# Altium schematic sheet AUDIO.SchDoc(AUDIO)
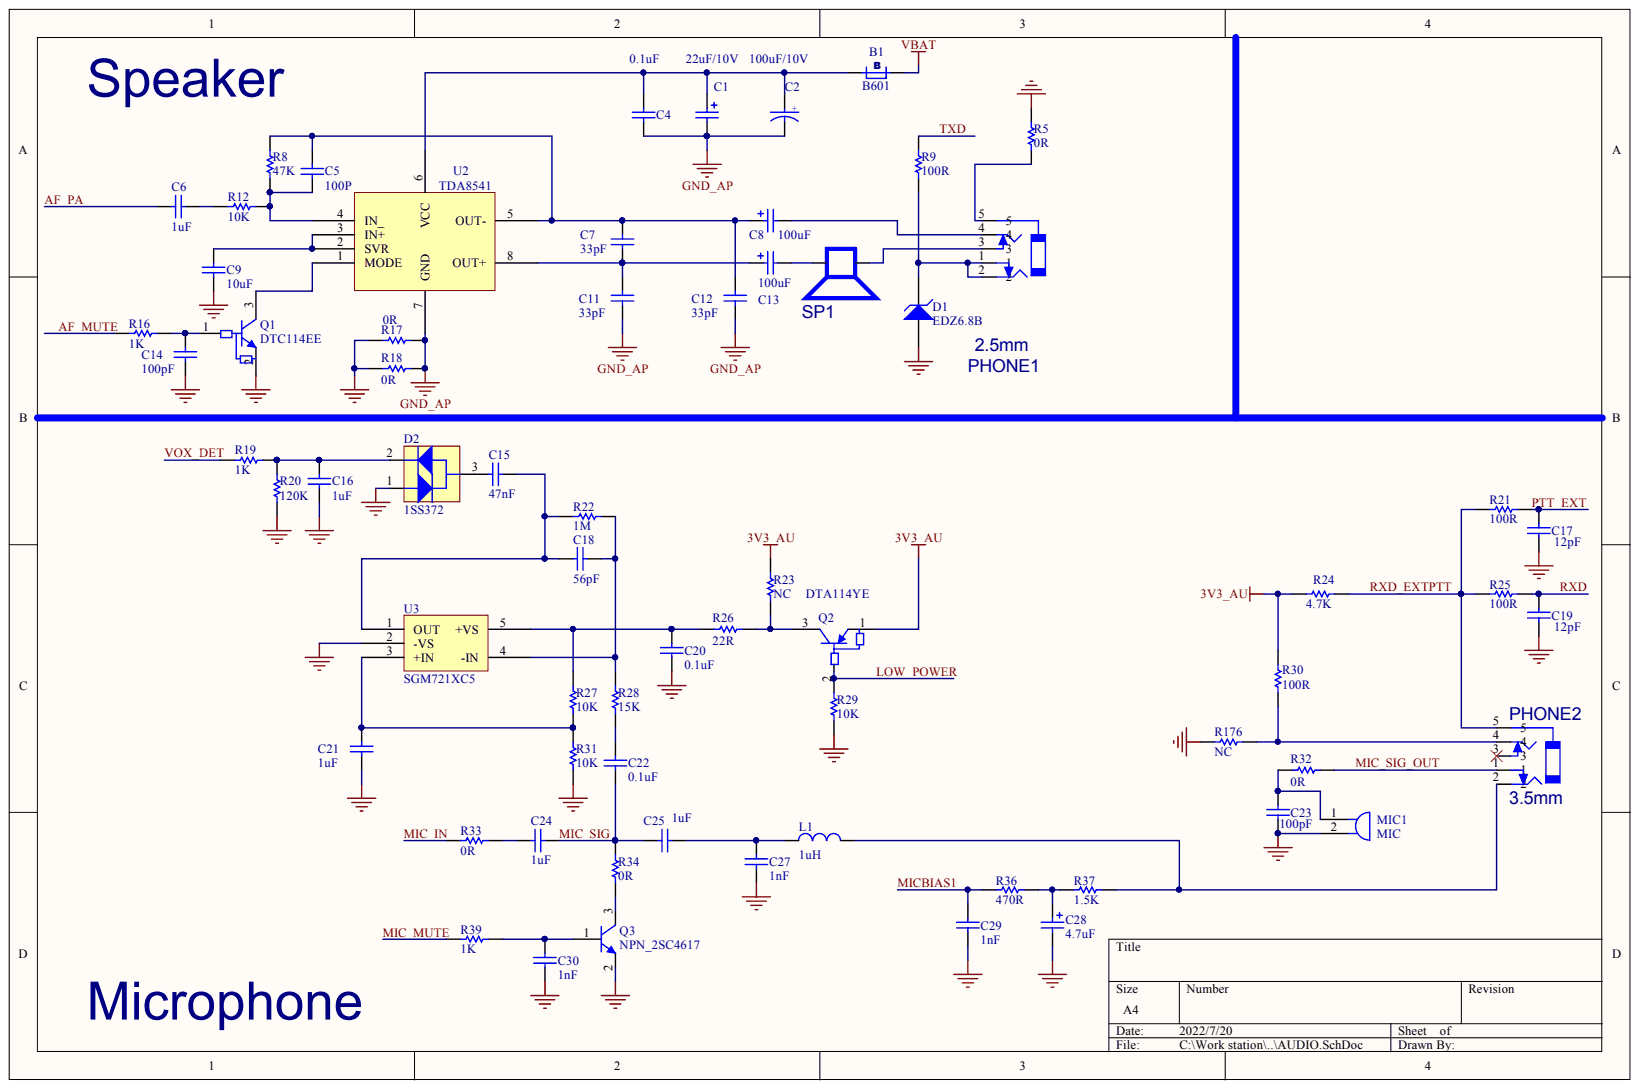
[diagram p0: AUDIO.SchDoc]

## Parts
B1  B601  [0603_MJ]
C1  22uF/10V  [0805]
C2  100uF/10V  [1210_Jun]
C4  0.1uF  [0402]
C5  100P  [0402]
C6  1uF  [0402]
C7  33pF  [0402]
C8  100uF  [C3216]
C9  10uF  [0603_MJ]
C11  33pF  [0402]
C12  33pF  [0402]
C13  100uF  [C3216]
C14  100pF  [0402]
C15  47nF  [0402]
C16  1uF  [0402]
C17  12pF  [0402]
C18  56pF  [0402]
C19  12pF  [0402]
C20  0.1uF  [0402]
C21  1uF  [0402]
C22  0.1uF  [0402]
C23  100pF  [0402]
C24  1uF  [0402]
C25  1uF  [0402]
C27  1nF  [0402]
C28  4.7uF  [0603]
C29  1nF  [0402]
C30  1nF  [0402]
D1  EDZ6.8B  [HVC131_SMALL]
D2  1SS372  [1ss372]
L1  1uH  [0603]
MIC1  MIC  [MIC-1]
PHONE1  2.5mm  [PHONE-2.5]
PHONE2  3.5mm  [PHONE-3.5]
Q1  DTC114EE  [SC-75]
Q2  DTA114YE  [DTA114YE_Jun]
Q3  NPN_2SC4617  [SC70-3_C]
R5  0R  [0402]
R8  47K  [0402]
R9  100R  [0402]
R12  10K  [0402]
R16  1K  [0402]
R17  0R  [0402]
R18  0R  [0402]
R19  1K  [0402]
R20  120K  [0402]
R21  100R  [0402]
R22  1M  [0402]
R23  NC  [0402]
R24  4.7K  [0402]
R25  100R  [0402]
R26  22R  [0402]
R27  10K  [0402]
R28  15K  [0402]
R29  10K  [0402]
R30  100R  [0402]
R31  10K  [0402]
R32  0R  [0402]
R33  0R  [0402]
R34  0R  [0402]
R36  470R  [0402]
R37  1.5K  [0402]
R39  1K  [0402]
R176  NC  [0402]
SP1  (no value)  [SP]
U2  TDA8541  [SOP-8-1.27]
U3  SGM721XC5  [SC-88A]

## Nets
3V3_AU: Q2.1 R23.2 R24.2 R30.2
GND: C9.2 C14.2 C16.2 C17.1 C19.1 C20.2 C21.2 C23.1 C27.2 C28.2 C29.1 C30.2 D1.1 D2.1 MIC1.2 Q1.2 Q3.2 R5.2 R17.2 R18.2 R20.2 R29.1 R31.1 R176.1 U3.2(-VS)
GND_AP: C1.2 C2.2 C4.1 C11.2 C12.2 R17.1 R18.1 U2.7(GND)
VBAT: B1.2
AF_MUTE: R16.1
AF_PA: C6.1
LOW_POWER: Q2.2 R29.2
MIC_IN: R33.2
MIC_MUTE: R39.2
MIC_SIG: C22.2 C24.2 C25.2 R34.2
MIC_SIG_OUT: PHONE2.1 R32.2
MICBIAS1: C29.2 R36.2
PTT_EXT: C17.2 R21.2
RXD: C19.2 R25.2
RXD_EXTPTT: PHONE2.5 R21.1 R24.1 R25.1
TXD: R9.2
VOX_DET: R19.1
NetB1_1: B1.1 C1.1 C2.1 C4.2 U2.6(VCC)
NetC5_1: C5.1 R8.1 R12.2 U2.4(IN_)
NetC5_2: C5.2 C7.1 C8.1 C12.1 R8.2 U2.5(OUT-)
NetC6_2: C6.2 R12.1
NetC7_2: C7.2 C11.1 C13.1 U2.8(OUT+)
NetC8_2: C8.2 PHONE1.4
NetC9_1: C9.1 U2.2(SVR) U2.3(IN+)
NetC13_2: C13.2 SP1.2
NetC14_1: C14.1 Q1.1 R16.2
NetC15_1: C15.1 D2.3
NetC15_2: C15.2 C18.1 R22.2 U3.1(OUT)
NetC16_1: C16.1 D2.2 R19.2 R20.1
NetC18_2: C18.2 R22.1 R28.2 U3.4(-IN)
NetC20_1: C20.1 R26.2 R27.2 U3.5(+VS)
NetC21_1: C21.1 R27.1 R31.2 U3.3(+IN)
NetC22_1: C22.1 R28.1
NetC23_2: C23.2 MIC1.1 R32.1
NetC24_1: C24.1 R33.1
NetC25_1: C25.1 C27.1 L1.2
NetC28_1: C28.1 R36.1 R37.2
NetC30_1: C30.1 Q3.1 R39.1
NetD1_2: D1.2 PHONE1.1 PHONE1.2 R9.1
NetL1_1: L1.1 PHONE2.2 R37.1
NetPHONE1_3: PHONE1.3 SP1.1
NetPHONE1_5: PHONE1.5 R5.1
NetPHONE2_4: PHONE2.4 R30.1 R176.2
NetQ1_3: Q1.3 U2.1(MODE)
NetQ2_3: Q2.3 R23.1 R26.1
NetQ3_3: Q3.3 R34.1

Not connected: PHONE2 3

## Library data of the parts
B1 = B: Component Kind: Standard; Library Name: SCH Lib.SCHLIB; Revision: July-2002: Re-released for DXP Platform.
C1 C8 C13 C28 = Cap Pol3: Polarized Capacitor (Surface Mount); Value: 4.7uF/10V; Component Kind: Standard; Library Name: SCH Lib.SCHLIB; Published: 8-Jun-2000; Revision: July-2002: Re-released for DXP Platform.; Signal Integrity: Cap Pol; Simulation: CAP; Publisher: Altium Limited
C2 = CAP_1210: Component Kind: Standard; Library Name: zySCHLIB_Z.SCHLIB
C4 C5 C7 C9 C11 C12 C14 C15 C17-C20 C22 C27 C29 C30 = Cap Semi_1: Capacitor (Semiconductor SIM Model); Value: 1uF; Component Kind: Standard; Library Name: SCH Lib.SCHLIB; Published: 8-Jun-2000; Revision: July-2002: Re-released for DXP Platform.; Signal Integrity: Cap Semi; Simulation: CAP; Publisher: Altium Limited
C6 C16 C21 C24 C25 = Cap Semi_1: Capacitor (Semiconductor SIM Model); Component Kind: Standard; Library Name: SCH Lib.SCHLIB; Published: 8-Jun-2000; Revision: July-2002: Re-released for DXP Platform.; Signal Integrity: Cap Semi; Simulation: CAP; Value: 1uF; Publisher: Altium Limited
C23 = Cap Semi_1: Capacitor (Semiconductor SIM Model); Value: 470pF; Component Kind: Standard; Library Name: SCH Lib.SCHLIB; Published: 8-Jun-2000; Revision: July-2002: Re-released for DXP Platform.; Signal Integrity: Cap Semi; Simulation: CAP; Publisher: Altium Limited
D1 = D Zener: Zener Diode; Manufacturer: Generic Components; Class: Discrete; SubClass: Diode - Zener; Component Kind: Standard; Library Name: Miscellaneous Devices.IntLib; Published: 08/06/2000; Signal Integrity: D Zener; Simulation: ZENER; Publisher: Altium Hobart Technology Centre
D2 = REC DIODE: Component Kind: Standard; Library Name: SCH Lib.SCHLIB
L1 = INDUCTOR: Component Kind: Standard; Library Name: SCH Lib.SCHLIB
MIC1 = Mic1: Microphone; Manufacturer: Generic Components; Component Kind: Standard; Library Name: Miscellaneous Devices.IntLib; Published: 1999
PHONE1 = JAKK_A: Component Kind: Standard; Library Name: zySCHLIB_Z.SCHLIB
PHONE2 = JAKK_A_1: Component Kind: Standard; Library Name: zySCHLIB_Z.SCHLIB
Q1 = DTC114EE_Jun: Component Kind: Standard; Library Name: zySCHLIB_Z.SCHLIB
Q2 = DTA114YE_Jun: Component Kind: Standard; Library Name: zySCHLIB_Z.SCHLIB
R5 R8 R9 R12 R17 R18 R20-R34 R36 R37 R176 = Res Semi: Semiconductor Resistor; Manufacturer: Generic Components; Class: Passive; Value: 1K; Component Kind: Standard; Library Name: Miscellaneous Devices.IntLib; Published: 08/06/2000; Signal Integrity: Res Semi; Simulation: RES; SubClass: Resistor; Publisher: Altium Hobart Technology Centre
R16 R19 R39 = Res Semi: Semiconductor Resistor; Manufacturer: Generic Components; Class: Passive; Component Kind: Standard; Library Name: Miscellaneous Devices.IntLib; Published: 08/06/2000; Signal Integrity: Res Semi; Simulation: RES; SubClass: Resistor; Value: 1K; Publisher: Altium Hobart Technology Centre
SP1 = SP: Component Kind: Standard
U3 = SGM721: Component Kind: Standard; Library Name: SCH Lib.SCHLIB
Q3 = NPN_2SC4617: Code_JEDEC: TO-226-AA; Component Kind: Standard; LatestRevisionDate: 17-Jul-2002; LatestRevisionNote: Re-released for DXP Platform.; PackageReference: TO-226; Published: 8-Jun-2000; Signal Integrity: NPN; Simulation: NPN; Library Name: zySCHLIB_Z.SCHLIB; Publisher: Altium Limited
U2 = TDA8541: Component Kind: Standard; Library Name: SCH Lib.SCHLIB
